# Altium schematic sheet Power_supplies.SchDoc
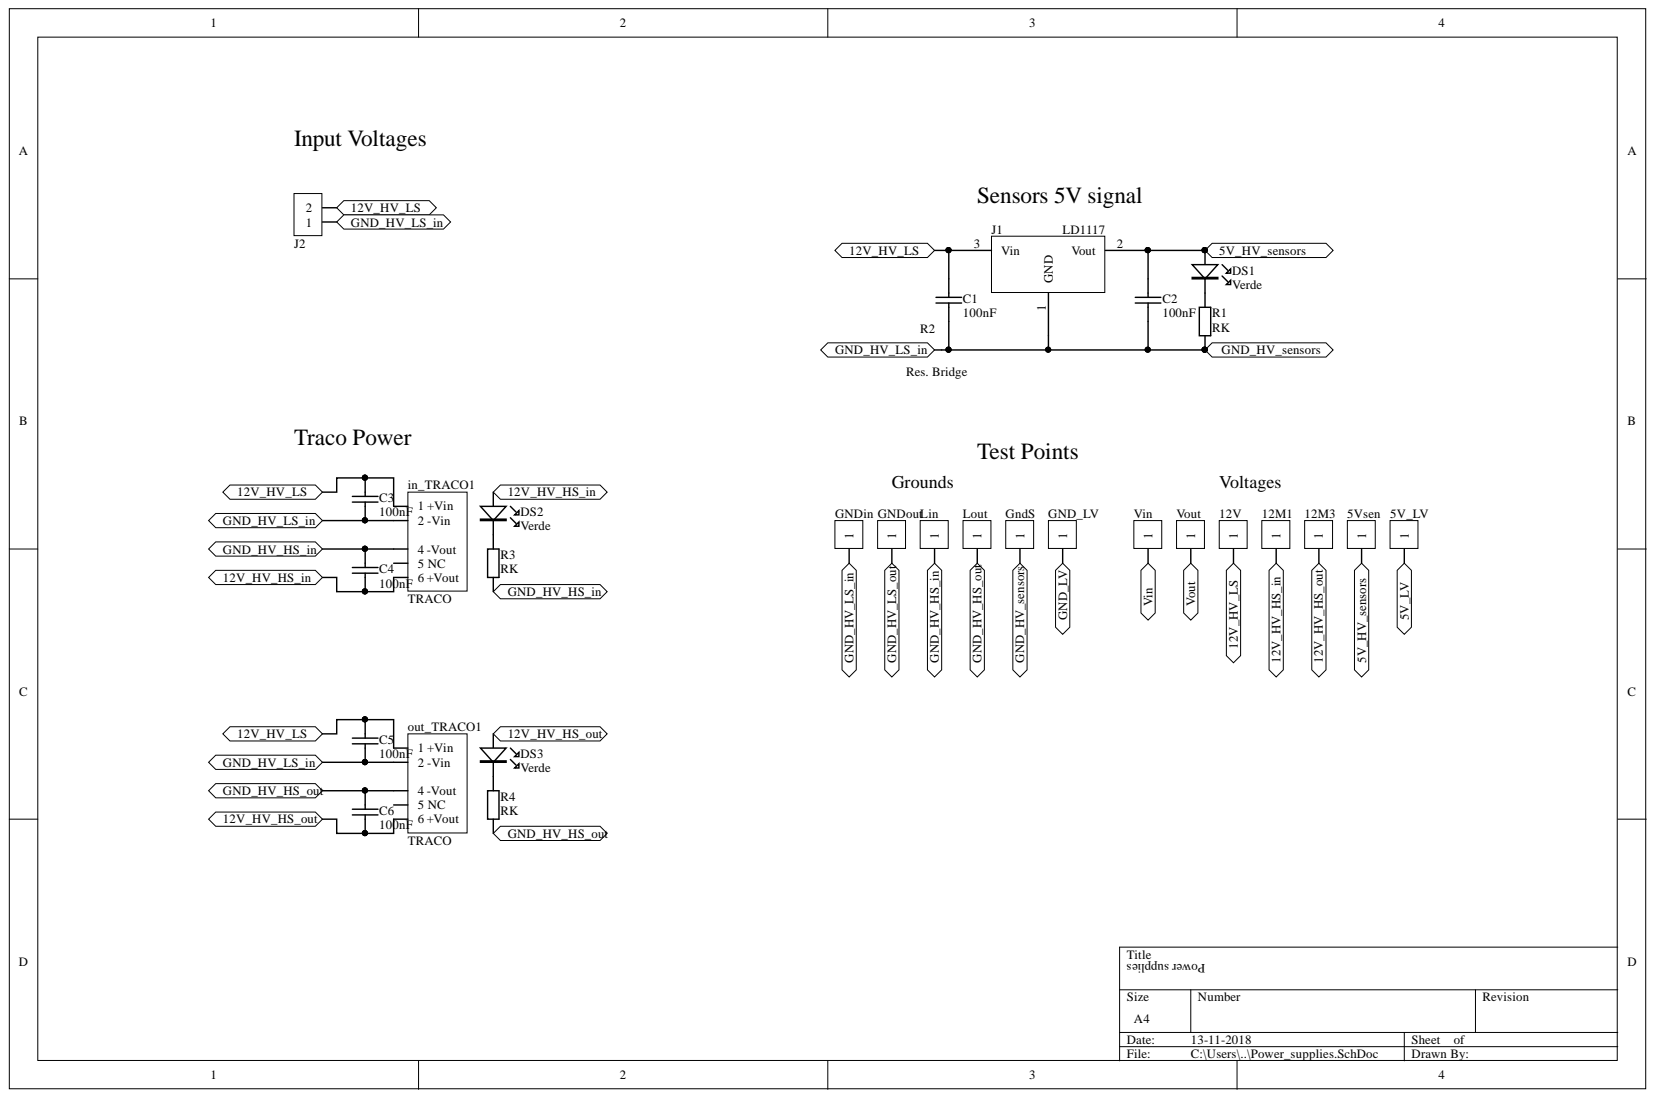
[diagram p0: Power_supplies.SchDoc]

## Parts
5V_LV  Test Point  [Test_Point]
5Vsen  Test Point  [Test_Point]
12M1  Test Point  [Test_Point]
12M3  Test Point  [Test_Point]
12V  Test Point  [Test_Point]
C1  100nF  [Cap100nF_AAU]
C2  100nF  [Cap100nF_AAU]
C3  100nF  [Cap100nF_AAU]
C4  100nF  [Cap100nF_AAU]
C5  100nF  [Cap100nF_AAU]
C6  100nF  [Cap100nF_AAU]
DS1  Verde  [Led 2mm]
DS2  Verde  [Led 2mm]
DS3  Verde  [Led 2mm]
GND_LV  Test Point  [Test_Point]
GNDin  Test Point  [Test_Point]
GNDout  Test Point  [Test_Point]
GndS  Test Point  [Test_Point]
in_TRACO1  TRACO  [TRACO_TMA1212S]
J1  LD1117  [SOT-223_LM1117]
J2  Cleva 2 vias  [Con2_Extron _3.5mmPitch]  (value not drawn)
Lin  Test Point  [Test_Point]
Lout  Test Point  [Test_Point]
out_TRACO1  TRACO  [TRACO_TMA1212S]
R1  RK  [1206]
R2  Res. Bridge  [1206]
R3  RK  [1206]
R4  RK  [1206]
Vin  Test Point  [Test_Point]
Vout  Test Point  [Test_Point]

## Ports
5V_HV_SENSORS, 5V_LV, 12V_HV_HS_IN, 12V_HV_HS_OUT, 12V_HV_LS, GND_HV_HS_IN, GND_HV_HS_OUT, GND_HV_LS_IN, GND_HV_LS_OUT, GND_HV_SENSORS, GND_LV, VIN, VOUT

## Nets
5V_LV: 5V_LV.1 ; port 5V_LV
12V_HV_LS: 12V.1 C1.2 C3.2 C5.2 in_TRACO1.1(1_+Vin) J1.3 J2.2 out_TRACO1.1(1_+Vin) ; port 12V_HV_LS
GND_LV: GND_LV.1 ; port GND_LV
Net5Vsen_1: 5Vsen.1 C2.2 DS1.A J1.2 ; port 5V_HV_SENSORS
Net12M1_1: 12M1.1 C4.1 DS2.A in_TRACO1.6(6_+Vout) ; port 12V_HV_HS_IN
Net12M3_1: 12M3.1 C6.1 DS3.A out_TRACO1.6(6_+Vout) ; port 12V_HV_HS_OUT
NetC1_1: C1.1 C2.1 GndS.1 J1.1 R1.2 R2.2 ; port GND_HV_SENSORS
NetC3_1: C3.1 C5.1 GNDin.1 in_TRACO1.2(2_-Vin) J2.1 out_TRACO1.2(2_-Vin) R2.1 ; port GND_HV_LS_IN
NetC4_2: C4.2 in_TRACO1.4(4_-Vout) Lin.1 R3.2 ; port GND_HV_HS_IN
NetC6_2: C6.2 Lout.1 out_TRACO1.4(4_-Vout) R4.2 ; port GND_HV_HS_OUT
NetDS1_K: DS1.K R1.1
NetDS2_K: DS2.K R3.1
NetDS3_K: DS3.K R4.1
NetGNDout_1: GNDout.1 ; port GND_HV_LS_OUT
NetVin_1: Vin.1 ; port VIN
NetVout_1: Vout.1 ; port VOUT

Not connected: in_TRACO1 5(5_NC); out_TRACO1 5(5_NC)

## Library data of the parts
C1-C6 = Condensador: Component Kind: Standard; Description: Condensador; Library Name: SCH_Lib.SchLib
DS1-DS3 = Led: Component Kind: Standard; Library Name: SCH_Lib.SchLib
in_TRACO1 out_TRACO1 = TRACO: TracoPower TMA1212S; Component Kind: Standard; Library Name: SCH_Lib.SchLib
J1 = LD1117: Linear voltage regulator; Component Kind: Standard; Library Name: SCH_Lib.SchLib
J2 = Con_2: Component Kind: Standard; Library Name: SCH_Lib.SchLib
R1 R3 R4 = Resistencia: Potencia: 1/4W; Component Kind: Standard; Description: Resistencia; Library Name: SCH_Lib.SchLib
R2 = Res. Bridge: Resistencia Bridge; Component Kind: Standard; Library Name: SCH_Lib.SchLib
5V_LV 5Vsen 12M1 12M3 12V GND_LV GNDin GNDout GndS Lin Lout Vin Vout = Test Point: Component Kind: Standard; Library Name: SCH_Lib.SchLib
